# Altium schematic sheet 03_Current_sensor.SchDoc(03_Current_sensor)
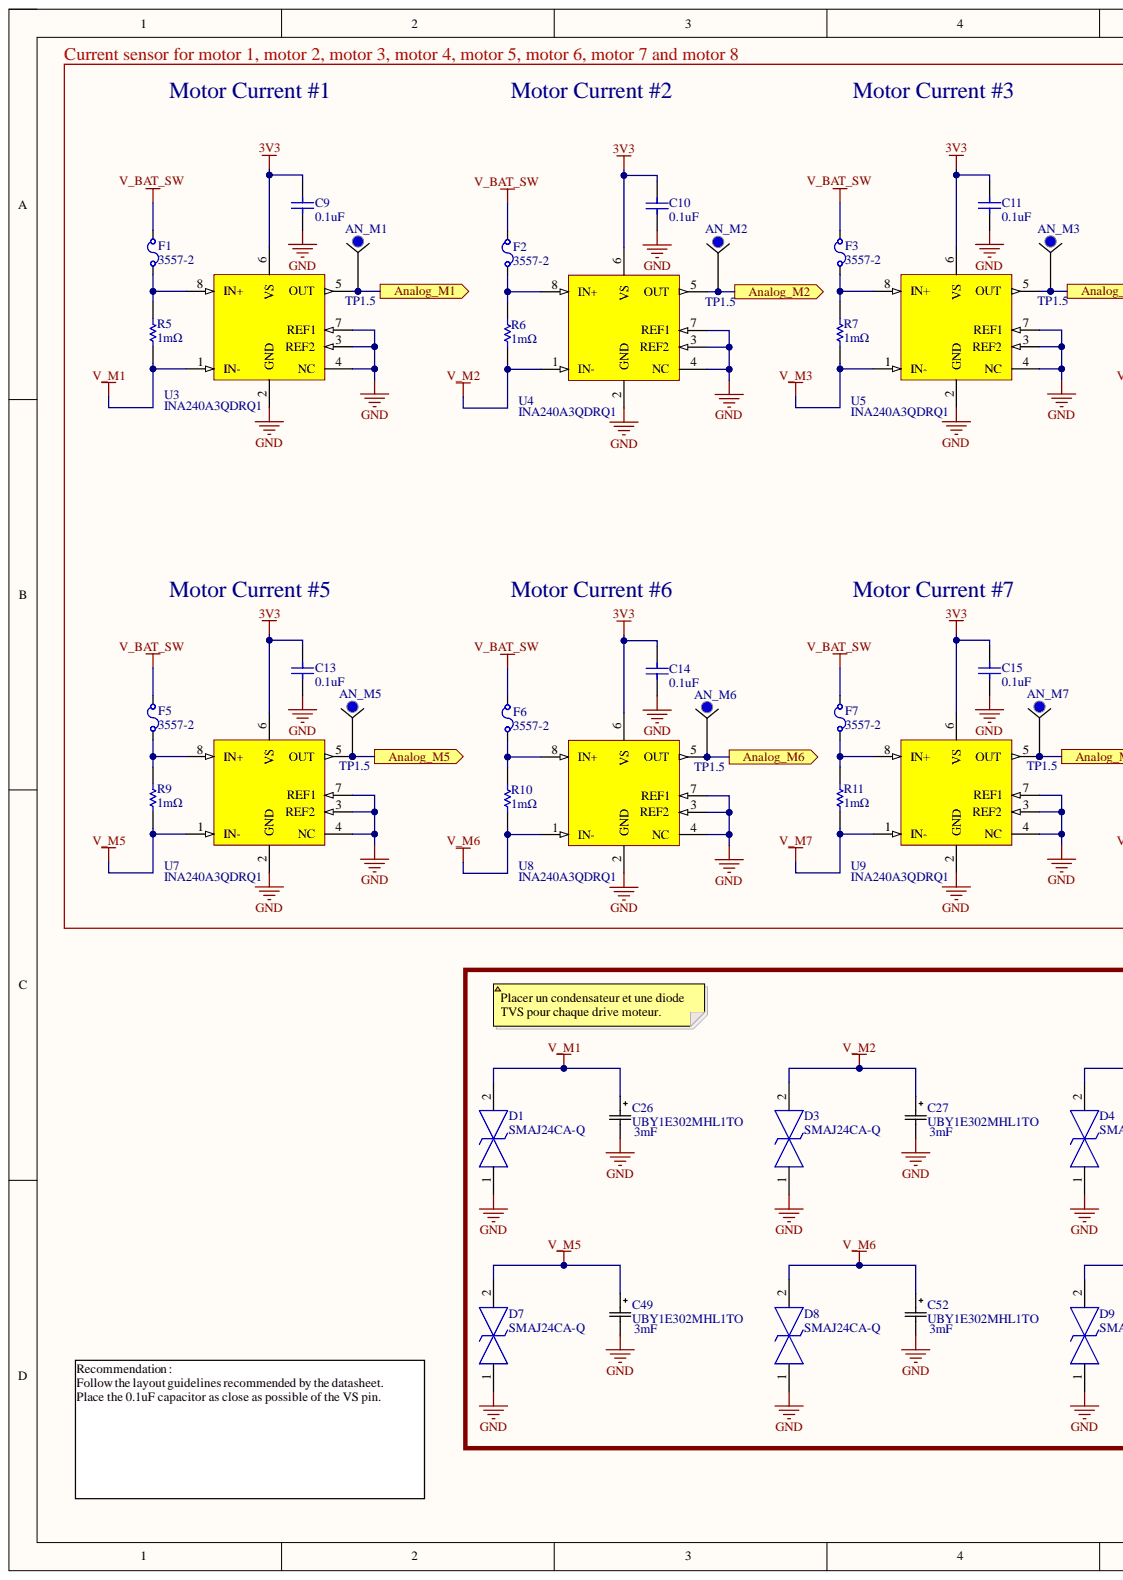
[diagram p0: 03_Current_sensor.SchDoc, left]
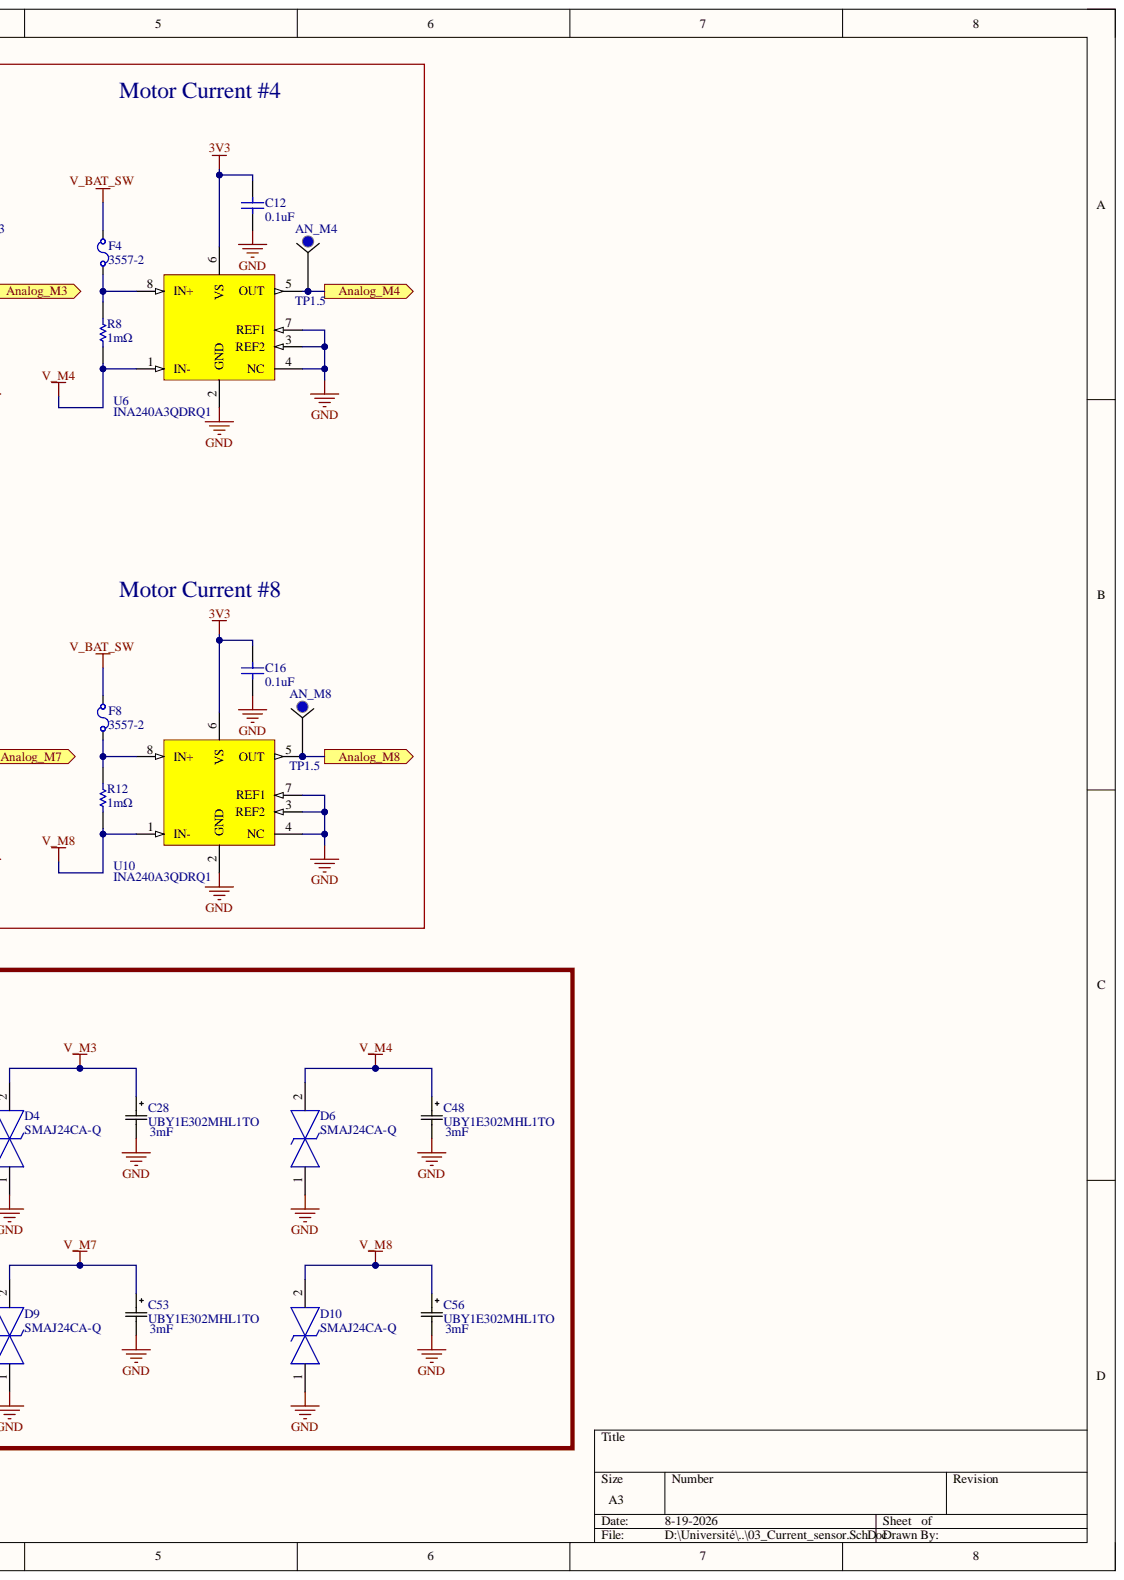
[diagram p1: 03_Current_sensor.SchDoc, right]

## Parts
AN_M1  TP1.5  [TP1.5]  (no BOM)
AN_M2  TP1.5  [TP1.5]  (no BOM)
AN_M3  TP1.5  [TP1.5]  (no BOM)
AN_M4  TP1.5  [TP1.5]  (no BOM)
AN_M5  TP1.5  [TP1.5]  (no BOM)
AN_M6  TP1.5  [TP1.5]  (no BOM)
AN_M7  TP1.5  [TP1.5]  (no BOM)
AN_M8  TP1.5  [TP1.5]  (no BOM)
C9  0.1uF  [CAPC200X125X95L50N]  (no BOM)
C10  0.1uF  [CAPC200X125X95L50N]  (no BOM)
C11  0.1uF  [CAPC200X125X95L50N]  (no BOM)
C12  0.1uF  [CAPC200X125X95L50N]  (no BOM)
C13  0.1uF  [CAPC200X125X95L50N]  (no BOM)
C14  0.1uF  [CAPC200X125X95L50N]  (no BOM)
C15  0.1uF  [CAPC200X125X95L50N]  (no BOM)
C16  0.1uF  [CAPC200X125X95L50N]  (no BOM)
C26  UBY1E302MHL1TO  value 3mF  [CAP_UBY_12P5X25_NCH]  (no BOM)
C27  UBY1E302MHL1TO  value 3mF  [CAP_UBY_12P5X25_NCH]  (no BOM)
C28  UBY1E302MHL1TO  value 3mF  [CAP_UBY_12P5X25_NCH]  (no BOM)
C48  UBY1E302MHL1TO  value 3mF  [CAP_UBY_12P5X25_NCH]  (no BOM)
C49  UBY1E302MHL1TO  value 3mF  [CAP_UBY_12P5X25_NCH]  (no BOM)
C52  UBY1E302MHL1TO  value 3mF  [CAP_UBY_12P5X25_NCH]  (no BOM)
C53  UBY1E302MHL1TO  value 3mF  [CAP_UBY_12P5X25_NCH]  (no BOM)
C56  UBY1E302MHL1TO  value 3mF  [CAP_UBY_12P5X25_NCH]  (no BOM)
D1  SMAJ24CA-Q  [DIONM5127X229N]  (no BOM)
D3  SMAJ24CA-Q  [DIONM5127X229N]  (no BOM)
D4  SMAJ24CA-Q  [DIONM5127X229N]  (no BOM)
D6  SMAJ24CA-Q  [DIONM5127X229N]  (no BOM)
D7  SMAJ24CA-Q  [DIONM5127X229N]  (no BOM)
D8  SMAJ24CA-Q  [DIONM5127X229N]  (no BOM)
D9  SMAJ24CA-Q  [DIONM5127X229N]  (no BOM)
D10  SMAJ24CA-Q  [DIONM5127X229N]  (no BOM)
F1  3557-2  [FUSE_3557-2]  (no BOM)
F2  3557-2  [FUSE_3557-2]  (no BOM)
F3  3557-2  [FUSE_3557-2]  (no BOM)
F4  3557-2  [FUSE_3557-2]  (no BOM)
F5  3557-2  [FUSE_3557-2]  (no BOM)
F6  3557-2  [FUSE_3557-2]  (no BOM)
F7  3557-2  [FUSE_3557-2]  (no BOM)
F8  3557-2  [FUSE_3557-2]  (no BOM)
R5  1mΩ  [RESC635X318X85L130N]  (no BOM)
R6  1mΩ  [RESC635X318X85L130N]  (no BOM)
R7  1mΩ  [RESC635X318X85L130N]  (no BOM)
R8  1mΩ  [RESC635X318X85L130N]  (no BOM)
R9  1mΩ  [RESC635X318X85L130N]  (no BOM)
R10  1mΩ  [RESC635X318X85L130N]  (no BOM)
R11  1mΩ  [RESC635X318X85L130N]  (no BOM)
R12  1mΩ  [RESC635X318X85L130N]  (no BOM)
U3  INA240A3QDRQ1  [SOIC8P127_490X599X175L84X41N]  (no BOM)
U4  INA240A3QDRQ1  [SOIC8P127_490X599X175L84X41N]  (no BOM)
U5  INA240A3QDRQ1  [SOIC8P127_490X599X175L84X41N]  (no BOM)
U6  INA240A3QDRQ1  [SOIC8P127_490X599X175L84X41N]  (no BOM)
U7  INA240A3QDRQ1  [SOIC8P127_490X599X175L84X41N]  (no BOM)
U8  INA240A3QDRQ1  [SOIC8P127_490X599X175L84X41N]  (no BOM)
U9  INA240A3QDRQ1  [SOIC8P127_490X599X175L84X41N]  (no BOM)
U10  INA240A3QDRQ1  [SOIC8P127_490X599X175L84X41N]  (no BOM)

## Ports
Analog_M1, Analog_M2, Analog_M3, Analog_M4, Analog_M5, Analog_M6, Analog_M7, Analog_M8

## Nets
3V3: C9.2 C10.2 C11.2 C12.2 C13.2 C14.2 C15.2 C16.2 U3.6(VS) U4.6(VS) U5.6(VS) U6.6(VS) U7.6(VS) U8.6(VS) U9.6(VS) U10.6(VS)
GND: C9.1 C10.1 C11.1 C12.1 C13.1 C14.1 C15.1 C16.1 C26.2 C27.2 C28.2 C48.2 C49.2 C52.2 C53.2 C56.2 D1.1 D3.1 D4.1 D6.1 D7.1 D8.1 D9.1 D10.1 U3.2(GND) U3.3(REF2) U3.4(NC) U3.7(REF1) U4.2(GND) U4.3(REF2) U4.4(NC) U4.7(REF1) U5.2(GND) U5.3(REF2) U5.4(NC) U5.7(REF1) U6.2(GND) U6.3(REF2) U6.4(NC) U6.7(REF1) U7.2(GND) U7.3(REF2) U7.4(NC) U7.7(REF1) U8.2(GND) U8.3(REF2) U8.4(NC) U8.7(REF1) U9.2(GND) U9.3(REF2) U9.4(NC) U9.7(REF1) U10.2(GND) U10.3(REF2) U10.4(NC) U10.7(REF1)
V_BAT_SW: F1.2 F2.2 F3.2 F4.2 F5.2 F6.2 F7.2 F8.2
V_M1: C26.1 D1.2 R5.2 U3.1(IN-)
V_M2: C27.1 D3.2 R6.2 U4.1(IN-)
V_M3: C28.1 D4.2 R7.2 U5.1(IN-)
V_M4: C48.1 D6.2 R8.2 U6.1(IN-)
V_M5: C49.1 D7.2 R9.2 U7.1(IN-)
V_M6: C52.1 D8.2 R10.2 U8.1(IN-)
V_M7: C53.1 D9.2 R11.2 U9.1(IN-)
V_M8: C56.1 D10.2 R12.2 U10.1(IN-)
Analog_M1: AN_M1.1 U3.5(OUT) ; port Analog_M1
Analog_M2: AN_M2.1 U4.5(OUT) ; port Analog_M2
Analog_M3: AN_M3.1 U5.5(OUT) ; port Analog_M3
Analog_M4: AN_M4.1 U6.5(OUT) ; port Analog_M4
Analog_M5: AN_M5.1 U7.5(OUT) ; port Analog_M5
Analog_M6: AN_M6.1 U8.5(OUT) ; port Analog_M6
Analog_M7: AN_M7.1 U9.5(OUT) ; port Analog_M7
Analog_M8: AN_M8.1 U10.5(OUT) ; port Analog_M8
NetF1_1: F1.1 R5.1 U3.8(IN+)
NetF2_1: F2.1 R6.1 U4.8(IN+)
NetF3_1: F3.1 R7.1 U5.8(IN+)
NetF4_1: F4.1 R8.1 U6.8(IN+)
NetF5_1: F5.1 R9.1 U7.8(IN+)
NetF6_1: F6.1 R10.1 U8.8(IN+)
NetF7_1: F7.1 R11.1 U9.8(IN+)
NetF8_1: F8.1 R12.1 U10.8(IN+)

## Library data of the parts
AN_M1-AN_M8 = TP1.5: Testpoint 1.5mm; Library Name: PCB_Power.SchLib
C9-C16 = CC0805KRX7R7BB104: CAP CER 0.1UF 16V X7R 0805; Manufacturer: Yageo; Detailed description: ±10% 16V Ceramic Capacitor X7R 0805 (2012 Metric); ComponentLink1Description: Datasheet; ComponentLink1URL: https://www.yageo.com/upload/media/product/productsearch/datasheet/mlcc/UPY-GPHC_X7R_6.3V-to-50V_18.pdf; Library Name: PCB_Power.SchLib; Manufacturer part number: CC0805KRX7R7BB104; Operating temperature: -55°C ~ 125°C; Price: 0.17; Supplier: Digikey; Supplier part number: 311-1142-1-ND; Value: 0.1uF
C26-C28 C48 C49 C52 C53 C56 = UBY1E302MHL1TO: 3000 µF 25 V Aluminum Electrolytic Capacitors Radial, Can 33mOhm @ 100kHz 3000 Hrs @ 135°C; Manufacturer_Name: Nichicon; LCSC_Part_Number: C1589947; Library Name: Protection_Lib.SchLib; Manufacturer_Part_Number: UBY1E302MHL1TO; Copyright: Copyright (C) 2025 Ultra Librarian. All rights reserved.
D1 D3 D4 D6-D10 = SMAJ24CA-Q: Bourns SMAJ24CA-Q, Bi-Directional TVS Diode, 400W, 2-Pin DO-214AC; Geometry.Height: 2.29mm; Datasheet Link: https://www.bourns.com/docs/Product-Datasheets/SMAJ-Q.pdf; LCSC_Part_Number: C1973119; Library Name: Protection_Lib.SchLib; Manufacturer_Name: Bourns; Manufacturer_Part_Number: SMAJ24CA-Q; Mouser Part Number: 652-SMAJ24CA-Q; Mouser Price/Stock: https://www.mouser.co.uk/ProductDetail/Bourns/SMAJ24CA-Q?qs=f9yNj16SXrJFTMu%2Ffx4vHg%3D%3D
F1-F8 = Keystone_3557-2: FUSE BLOCK BLADE 500V 30A PCB; Manufacturer: Keystone Electronics; Area: 133.32; Detailed Description: Fuse Block 500V Circuit Blade Through Hole; ComponentLink1Description: Datasheet; ComponentLink1URL: http://keyelco.com/userAssets/file/M65p41.pdf; LCSC_Part_Number: Ne_pas_ajouter; Library Name: PCB_Power.SchLib; Manufacturer Part Number: 3557-2; Operating Temperature: -; Price: 1.78; Supplier: Digikey; Supplier Part Number: 36-3557-2-ND
R5-R12 = Riedon_CSR2512C0R001F: RES 0.001 OHM 1% 3W 2512; Manufacturer: Riedon; Area: 19; Detailed Description: 1 ±1% 3W Chip Resistor 2512 (6432 Metric) Current Sense, Non-Inductive Metal Element; ComponentLink1Description: Datasheet; ComponentLink1URL: https://riedon.com/media/pdf/CSR.pdf; LCSC_Part_Number: C6490437; Library Name: PCB_Power.SchLib; Manufacturer Part Number: CSR2512C0R001F; Operating Temperature: -55°C ~ 170°C; Price: 0.94; Supplier: Digikey; Supplier Part Number: 696-1185-1-ND; Value: 1mΩ
U3-U10 = TI_INA240A3QDRQ1: IC CURR SENSE 1 CIRCUIT 8SOIC; Manufacturer: Texas Instruments; Area: 20; Detailed Description: Current Sense Amplifier Circuit - 8-SOIC; ComponentLink1Description: Datasheet; ComponentLink1URL: http://www.ti.com/general/docs/suppproductinfo.tsp?distId=10&gotoUrl=http%3A%2F%2Fwww.ti.com%2Flit%2Fgpn%2Fina240-q1; LCSC_Part_Number: C1512996; Library Name: PCB_Power.SchLib; Manufacturer Part Number: INA240A3QDRQ1; Operating Temperature: -40°C ~ 125°C (TA); Price: 5.05; Supplier: Digikey; Supplier Part Number: 296-INA240A3QDRQ1CT-ND
